# Altium schematic sheet Motion_detector_ESP32.SchDoc
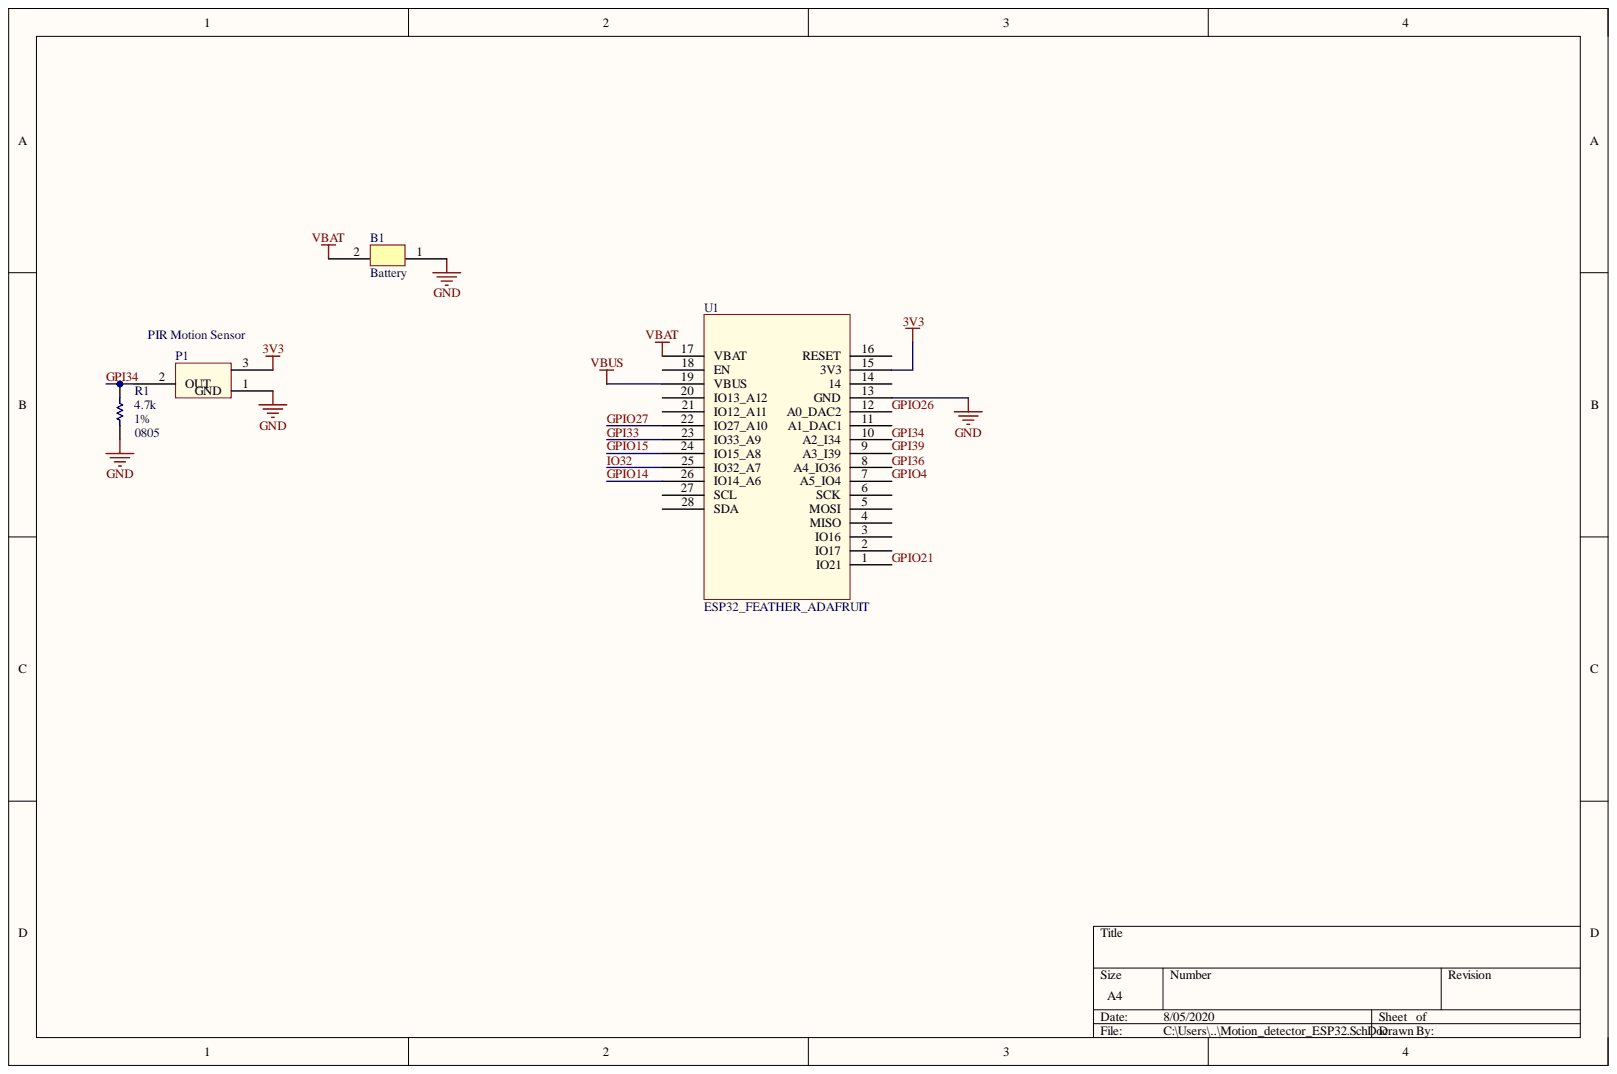
[diagram p0: Motion_detector_ESP32.SchDoc]

## Parts
B1  Battery  [battery-3.7V-1200mAh]
P1  PIR Motion Sensor  [EKMB1301111K]  (value not drawn)
R1  value 4.7k  Package: 0805  Precision: 1%  [RES_0805]  (hidden comment: RES - 0805)
U1  ESP32_FEATHER_ADAFRUIT  [ESP32_FEATHER]

## Nets
3V3: P1.3 U1.15(3V3)
GND: B1.1 P1.1 R1.1 U1.13(GND)
VBAT: B1.2 U1.17(VBAT)
VBUS: U1.19(VBUS)
GPI33: U1.23(IO33_A9)
GPI34: P1.2 R1.2 U1.10(A2_I34)
GPI36: U1.8(A4_IO36)
GPI39: U1.9(A3_I39)
GPIO4: U1.7(A5_IO4)
GPIO14: U1.26(IO14_A6)
GPIO15: U1.24(IO15_A8)
GPIO21: U1.1(IO21)
GPIO26: U1.12(A0_DAC2)
GPIO27: U1.22(IO27_A10)
IO32: U1.25(IO32_A7)

Not connected: U1 2(IO17) 3(IO16) 4(MISO) 5(MOSI) 6(SCK) 11(A1_DAC1) 14 16(RESET) 18(EN) 20(IO13_A12) 21(IO12_A11) 27(SCL) 28(SDA)

## Library data of the parts
P1 = PIR Motion Sensor: Sensor PIR (Passive Infrared) 197" (5m) 16.4' Polyethylene, White Lens; Component Kind: Standard; Library Name: S7CAS_Lib2019_REV1_00.IntLib
B1 = Battery: Component Kind: Standard; Library Name: S7CAS_Lib2019_REV1_00.IntLib
R1 = RES - 0805: Component Kind: Standard; Description: RES - 0805; Library Name: S7CAS_Lib2019_REV1_00.IntLib; CreatedBy: Jonathan Bouchard
U1 = ESP32_FEATHER_ADAFRUIT: Component Kind: Standard; Library Name: S7CAS_Lib2019_REV1_00.IntLib
